# Altium schematic sheet BMSSlaveSchematic.SchDoc
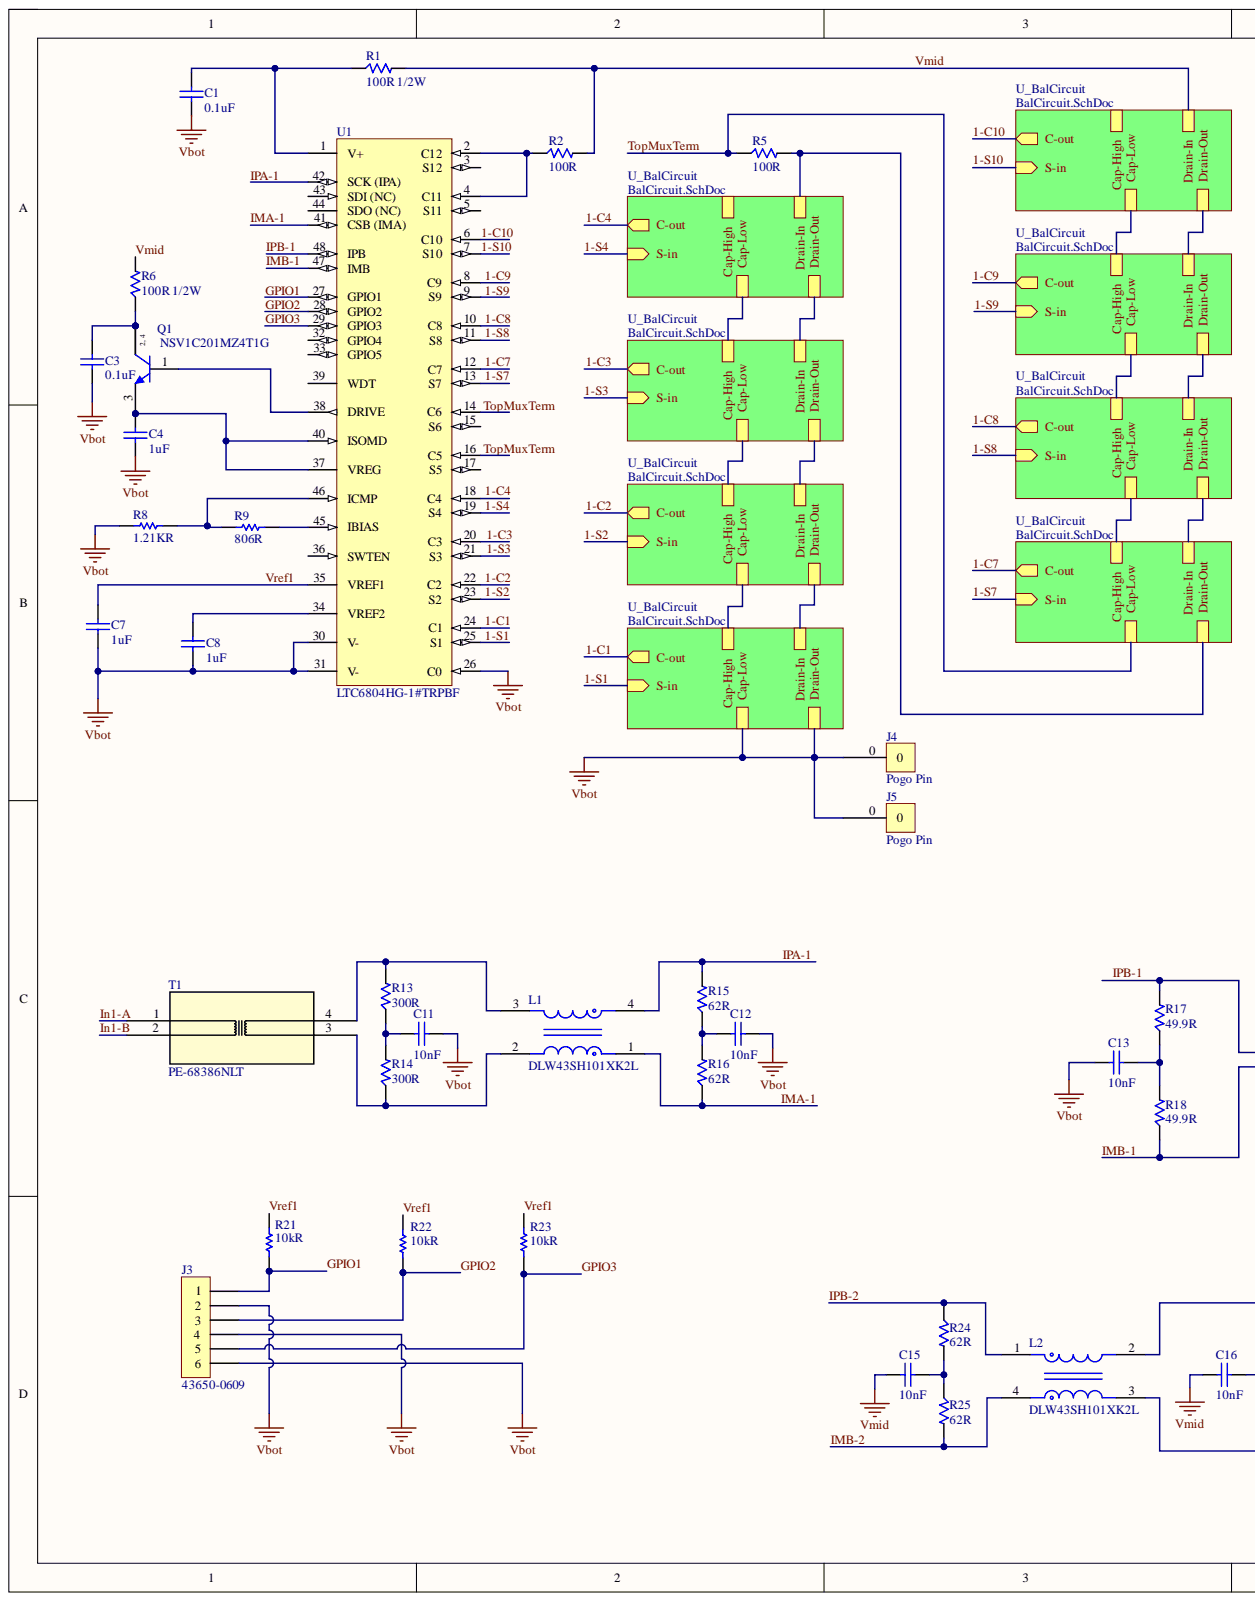
[diagram p0: BMSSlaveSchematic.SchDoc, left]
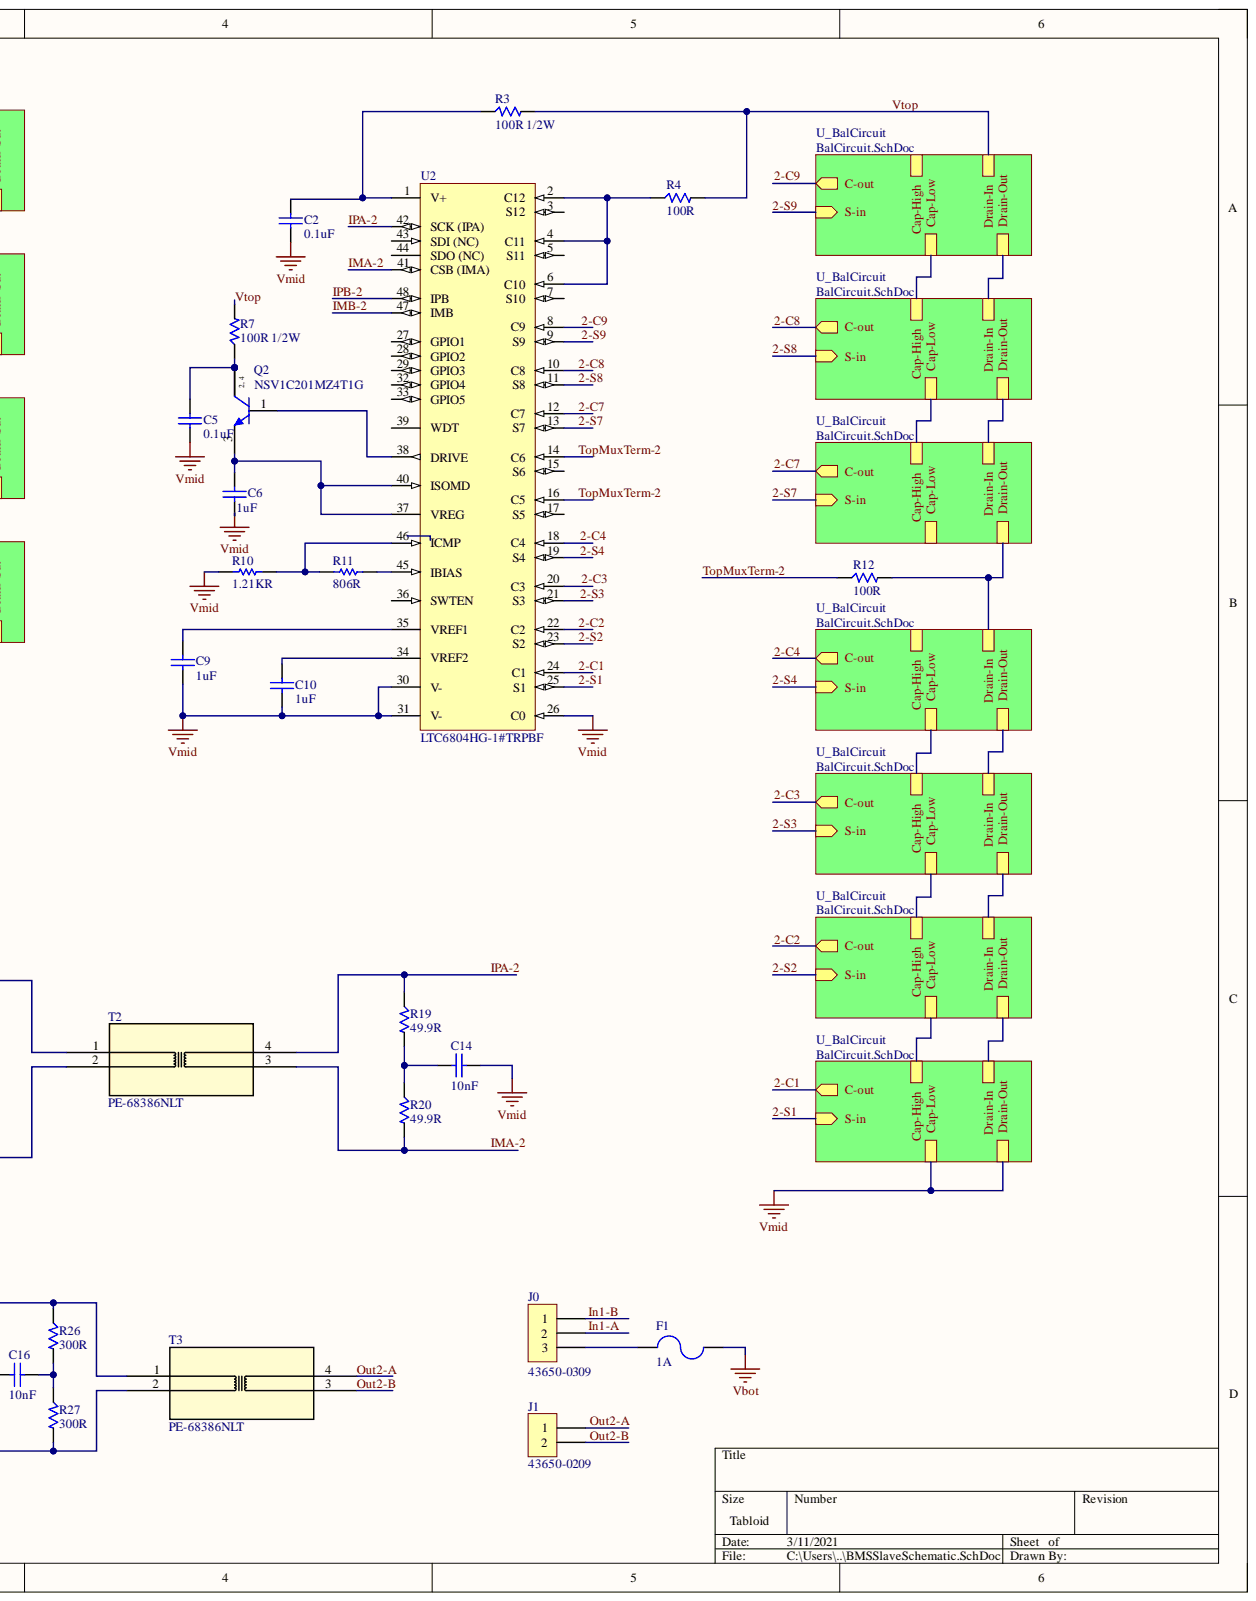
[diagram p1: BMSSlaveSchematic.SchDoc, right]

## Parts
C1  0.1uF  [CAPC2013X145X48NL20T23]
C2  0.1uF  [CAPC2013X145X48NL20T23]
C3  0.1uF  [CAPC2013X145X48NL20T23]
C4  1uF  [CAPC2013X140X50NL20T25]
C5  0.1uF  [CAPC2013X145X48NL20T23]
C6  1uF  [CAPC2013X140X50NL20T25]
C7  1uF  [CAPC2013X140X50NL20T25]
C8  1uF  [CAPC2013X140X50NL20T25]
C9  1uF  [CAPC2013X140X50NL20T25]
C10  1uF  [CAPC2013X140X50NL20T25]
C11  10nF  [Cap, 5mm TH, Ceramic]
C12  10nF  [Cap, 5mm TH, Ceramic]
C13  10nF  [Cap, 5mm TH, Ceramic]
C14  10nF  [Cap, 5mm TH, Ceramic]
C15  10nF  [Cap, 5mm TH, Ceramic]
C16  10nF  [Cap, 5mm TH, Ceramic]
F1  1A  [OMT125]
J0  43650-0309  [43650-0309]
J1  43650-0209  [43650-0209]
J3  43650-0609  [43650-0609]
J4  Pogo Pin  [0929-7]
J5  Pogo Pin  [0929-7]
L1  DLW43SH101XK2L  [FP-DLW43-0_2-t2_6-e0_6-MFG]
L2  DLW43SH101XK2L  [FP-DLW43-0_2-t2_6-e0_6-MFG]
Q1  NSV1C201MZ4T1G  [ONSC-SOT-223-4-318E-04_V]
Q2  NSV1C201MZ4T1G  [ONSC-SOT-223-4-318E-04_V]
R1  100R 1/2W  Resistance: 100R  [Th100R-12W]
R2  100R  [Q Watt]
R3  100R 1/2W  Resistance: 100R  [Th100R-12W]
R4  100R  [Q Watt]
R5  100R  [Q Watt]
R6  100R 1/2W  Resistance: 100R  [Th100R-12W]
R7  100R 1/2W  Resistance: 100R  [Th100R-12W]
R8  1.21KR  [RESC3216X70X50NL05T20]
R9  806R  [RESC3216X70X50NL05T20]
R10  1.21KR  [RESC3216X70X50NL05T20]
R11  806R  [RESC3216X70X50NL05T20]
R12  100R  [Q Watt]
R13  300R  [Q Watt]
R14  300R  [Q Watt]
R15  62R  [Q Watt]
R16  62R  [Q Watt]
R17  49.9R  [Q Watt]
R18  49.9R  [Q Watt]
R19  49.9R  [Q Watt]
R20  49.9R  [Q Watt]
R21  10kR  [RESC3216X70X50NL05T20]
R22  10kR  [RESC3216X70X50NL05T20]
R23  10kR  [RESC3216X70X50NL05T20]
R24  62R  [Q Watt]
R25  62R  [Q Watt]
R26  300R  [Q Watt]
R27  300R  [Q Watt]
T1  PE-68386NLT  [PE-68386NL_PUL]
T2  PE-68386NLT  [PE-68386NL_PUL]
T3  PE-68386NLT  [PE-68386NL_PUL]
U1  LTC6804HG-1#TRPBF  [LT-G-48_M]
U2  LTC6804HG-1#TRPBF  [LT-G-48_M]

## Nets
Vbot: C1.1 C3.2 C4.1 C7.1 C8.1 C11.1 C12.1 C13.2 F1.2 J3.2 J3.4 J3.6 J4.0 J5.0 R8.1 U1.26(C0) U1.30(V-) U1.31(V-)
1-C1: U1.24(C1)
1-C2: U1.22(C2)
1-C3: U1.20(C3)
1-C4: U1.18(C4)
1-C7: U1.12(C7)
1-C8: U1.10(C8)
1-C9: U1.8(C9)
1-C10: U1.6(C10)
1-S1: U1.25(S1)
1-S2: U1.23(S2)
1-S3: U1.21(S3)
1-S4: U1.19(S4)
1-S7: U1.13(S7)
1-S8: U1.11(S8)
1-S9: U1.9(S9)
1-S10: U1.7(S10)
2-C1: U2.24(C1)
2-C2: U2.22(C2)
2-C3: U2.20(C3)
2-C4: U2.18(C4)
2-C7: U2.12(C7)
2-C8: U2.10(C8)
2-C9: U2.8(C9)
2-S1: U2.25(S1)
2-S2: U2.23(S2)
2-S3: U2.21(S3)
2-S4: U2.19(S4)
2-S7: U2.13(S7)
2-S8: U2.11(S8)
2-S9: U2.9(S9)
GPIO1: J3.1 R21.1 U1.27(GPIO1)
GPIO2: J3.3 R22.1 U1.28(GPIO2)
GPIO3: J3.5 R23.1 U1.29(GPIO3)
IMA-1: L1.1 R16.1 U1.41(CSB_(IMA))
IMA-2: R20.2 T2.3 U2.41(CSB_(IMA))
IMB-1: R18.1 T2.2 U1.47(IMB)
IMB-2: L2.4 R25.2 U2.47(IMB)
In1-A: J0.2 T1.1
In1-B: J0.1 T1.2
IPA-1: L1.4 R15.2 U1.42(SCK_(IPA))
IPA-2: R19.1 T2.4 U2.42(SCK_(IPA))
IPB-1: R17.2 T2.1 U1.48(IPB)
IPB-2: L2.1 R24.1 U2.48(IPB)
Out2-A: J1.1 T3.4
Out2-B: J1.2 T3.3
TopMuxTerm: R5.1 U1.14(C6) U1.16(C5)
TopMuxTerm-2: R12.1 U2.14(C6) U2.16(C5)
Vmid: C2.1 C5.2 C6.1 C9.1 C10.1 C14.1 C15.1 C16.1 R1.2 R2.2 R6.2 R10.1 U2.26(C0) U2.30(V-) U2.31(V-)
Vref1: C7.2 R21.2 R22.2 R23.2 U1.35(VREF1)
Vtop: R3.2 R4.2 R7.2
NetC1_2: C1.2 R1.1 U1.1(V+)
NetC2_2: C2.2 R3.1 U2.1(V+)
NetC3_1: C3.1 Q1.2 Q1.4 R6.1
NetC4_2: C4.2 Q1.3 U1.37(VREG) U1.40(ISOMD)
NetC5_1: C5.1 Q2.2 Q2.4 R7.1
NetC6_2: C6.2 Q2.3 U2.37(VREG) U2.40(ISOMD)
NetC8_2: C8.2 U1.34(VREF2)
NetC9_2: C9.2 U2.35(VREF1)
NetC10_2: C10.2 U2.34(VREF2)
NetC11_2: C11.2 R13.1 R14.2
NetC12_2: C12.2 R15.1 R16.2
NetC13_1: C13.1 R17.1 R18.2
NetC14_2: C14.2 R19.2 R20.1
NetC15_2: C15.2 R24.2 R25.1
NetC16_2: C16.2 R26.2 R27.1
NetF1_1: F1.1 J0.3
NetL1_2: L1.2 R14.1 T1.3
NetL1_3: L1.3 R13.2 T1.4
NetL2_2: L2.2 R26.1 T3.1
NetL2_3: L2.3 R27.2 T3.2
NetQ1_1: Q1.1 U1.38(DRIVE)
NetQ2_1: Q2.1 U2.38(DRIVE)
NetR2_1: R2.1 U1.2(C12) U1.4(C11)
NetR4_1: R4.1 U2.2(C12) U2.4(C11) U2.6(C10)
NetR5_2: R5.2
NetR8_2: R8.2 R9.1 U1.46(ICMP)
NetR9_2: R9.2 U1.45(IBIAS)
NetR10_2: R10.2 R11.1 U2.46(ICMP)
NetR11_2: R11.2 U2.45(IBIAS)
NetR12_2: R12.2
NetU1_3: U1.3(S12)
NetU1_5: U1.5(S11)
NetU1_15: U1.15(S6)
NetU1_17: U1.17(S5)
NetU1_32: U1.32(GPIO4)
NetU1_33: U1.33(GPIO5)
NetU1_36: U1.36(SWTEN)
NetU1_39: U1.39(WDT)
NetU1_43: U1.43(SDI_(NC))
NetU1_44: U1.44(SDO_(NC))
NetU2_3: U2.3(S12)
NetU2_5: U2.5(S11)
NetU2_7: U2.7(S10)
NetU2_15: U2.15(S6)
NetU2_17: U2.17(S5)
NetU2_27: U2.27(GPIO1)
NetU2_28: U2.28(GPIO2)
NetU2_29: U2.29(GPIO3)
NetU2_32: U2.32(GPIO4)
NetU2_33: U2.33(GPIO5)
NetU2_36: U2.36(SWTEN)
NetU2_39: U2.39(WDT)
NetU2_43: U2.43(SDI_(NC))
NetU2_44: U2.44(SDO_(NC))
Cap-High:
Cap-Low:
Drain-In:

## Library data of the parts
C1-C3 C5 = CMP-2007-01705-1: Manufacturer: Vitramon; Part Number: VJ0805Y104KXAMR; Component Kind: Standard; Library Name: Altium Content Vault
C4 C6-C10 = CMP-1036-04765-2: Manufacturer: AVX Interconnect / Elco; Part Number: 08055G105ZAT2A; Component Kind: Standard; Library Name: Altium Content Vault
C11-C16 = Cap, 5MM TH, Ceramic: Generic Cap, 5MM TH, Ceramic; TargetLibrary: RITRacing-Passives; Value: Value?; Component Kind: Standard; Library Name: Passives.intlib; Published: 22Oct2016; Status: Active; Publisher: T.Tallo
F1 = OMT125: SCHURTER   3404.0114.11   FUSE, 1A, 125VDC, SMT; Approvals: cURus,cULus,UL; Blow Characteristic: TIME DELAY; Body Material: Thermoplastic; Fuse Size: 7.4 X 3.1mm; Fuse Type: Board Mount (Cartridge Style Excluded); Max Voltage Rating (AC): 125V; Power Dissipation: 88mW; Case/Package: SMD/SMT; Category: Fuses; Component Kind: Standard; ComponentLink1Description: https://datasheet.ciiva.com/4486/typ-omt-125-14932-4486467.pdf?src-supplier=Mouser; ComponentLink1URL: https://datasheet.ciiva.com/4486/typ-omt-125-14932-4486467.pdf?src-supplier=Mouser; ComponentLink2Description: https://datasheet.ciiva.com/26876/getdatasheetpartid-627585-26876503.pdf?src-supplier=Verical; ComponentLink2URL: https://datasheet.ciiva.com/26876/getdatasheetpartid-627585-26876503.pdf?src-supplier=Verical; ComponentLink3Description: https://datasheet.ciiva.com/17402/typ-omt-125-17402981.pdf?src-supplier=Avnet+Europe; ComponentLink3URL: https://datasheet.ciiva.com/17402/typ-omt-125-17402981.pdf?src-supplier=Avnet+Europe; ComponentLink4Description: https://datasheet.ciiva.com/1549/typ-omt-125-1549883.pdf?src-supplier=Element14; ComponentLink4URL: https://datasheet.ciiva.com/1549/typ-omt-125-1549883.pdf?src-supplier=Element14; ComponentLink5Description: https://datasheet.ciiva.com/8264/typ-omt-125-8264396.pdf?src-supplier=Avnet; ComponentLink5URL: https://datasheet.ciiva.com/8264/typ-omt-125-8264396.pdf?src-supplier=Avnet; Contact Material: Copper Alloy; Contact Plating: Tin; Current: 1A; Current Rating: 1A; Depth: 3.1mm; Flammability Rating: UL94 V-0; Fuse Current: 1A; Height: 0.102inch; Housing Material: Thermoplastic; Length: 7.4mm; Library Name: otherComponents.SchLib; Manufacturer 1: Schurter; Manufacturer Part Number 1: 3404.0114.11; Max Operating Temperature: 85°C; Max Voltage Rating (DC): 125V; Min Operating Temperature: -55°C; Mount: Surface Mount,PCB; Number of Pins: 2; Package Quantity: 100; Packaging: Bag; Pricing 1: 1=0.67000, 50=0.56400, 100=0.43100, 500=0.42300, 1000=0.38171 (USD); Radiation Hardening: No; REACH SVHC: No SVHC; RoHS: Yes; RoHS Compliant: Yes; Series: OMT 125; Stock 1: 1439; Supplier 1: Digi-Key; Terminal Material: Tin; Termination: SMD/SMT; Voltage Rating: 125V; Voltage Rating (AC): 125V; Voltage Rating (DC): 125V; Width: 0.122inch
J0 = 43650-0309: Molex 3 Position Board Header, RIght Angle; TargetLibrary: RITRacing-Connectors; Voltage: 600V; Component Kind: Standard; ComponentLink1Description: Datasheet; ComponentLink1URL: http://www.mouser.com/ds/2/418/NG_CD_1445088_A1-614413.pdf; Library Name: Connectors.IntLib; Manufacturer: Molex; PartNumber: 43650-0309; Published: 13Aug2018; Status: Active; Publisher: T.Tallo
J1 = 43650-0209: Molex 2 Position Board Header, RIght Angle; TargetLibrary: RITRacing-Connectors; Voltage: 600V; Component Kind: Standard; ComponentLink1Description: Datasheet; ComponentLink1URL: http://www.mouser.com/ds/2/418/NG_CD_1445088_A1-614413.pdf; Library Name: Connectors.IntLib; Manufacturer: Molex; PartNumber: 43650-0209; Published: 13Aug2018; Status: Active; Publisher: T.Tallo
J3 = 43650-0609: Molex 6 Position Board Header, RIght Angle; TargetLibrary: RITRacing-Connectors; Voltage: 600V; Component Kind: Standard; ComponentLink1Description: Datasheet; ComponentLink1URL: http://www.mouser.com/ds/2/418/NG_CD_1445088_A1-614413.pdf; Library Name: Connectors.IntLib; Manufacturer: Molex; PartNumber: 43650-0609; Published: 13Aug2018; Status: Active; Publisher: T.Tallo
J4 J5 = 0929-0-15-20-75-14-11-0: Component Kind: Standard; Library Name: SinglePad.SchLib
L1 L2 = CMP-06045-000059-1: CMC 100UH 200MA 2LN SMD AEC-Q200; DC Resistance (DCR): 2R; Number of Lines: 2; Shielding: Unshielded; Test Frequency: 1MHz; Tolerance: 50%; Voltage Rating: 50V; Case Code (Imperial): 1812; Case Code (Metric): 4532; Case/Package: 1812; Component Kind: Standard; Current: 200mA; Current Rating: 200mA; DC Current: 200mA; Depth: 3.4mm; Height: 2.6mm; Inductance: 100uH; Lead Free: Lead Free; Length: 4.5mm; Library Name: Altium Content Vault; Max DC Current: 200mA; Max Operating Temperature: 125°C; Military Standard: Not; Min Operating Temperature: -40°C; Mount: Surface Mount; Package Quantity: 1; Packaging: Tape and Reel; Radiation Hardening: No; REACH SVHC: No SVHC; Termination: SMD/SMT; Weight: 0.005997oz; Width: 0.126inch
Q1 Q2 = CMP-1048-00450-1: 100 V, 2 A, Low VCE(sat), NPN Transistor, 4-Pin SOT-223, Pb-Free, Tape and Reel; Manufacturer: On Semiconductor; IC Continuous (A): 2; Note1: 0; Component Kind: Standard; ComponentLink1Description: Manufacturer URL; ComponentLink1URL: http://www.onsemi.com/; ComponentLink2Description: Datasheet; ComponentLink2URL: http://www.onsemi.cn/pub_link/Collateral/NSS1C201MZ4-D.PDF; ComponentLink3Description: Package Specification; ComponentLink3URL: http://www.onsemi.com/pub_link/Collateral/318E-04.PDF; DatasheetVersion: Rev. 4; Library Name: Altium Content Vault; Mounting Technology: Surface Mount; PackageDescription: 4-Pin Small Outline Transistor, Body 6.5 x 3.5 mm, Pitch 2.3 mm; PackageReference: SOT-223-4-318E-04; PackageVersion: Rev. N, 08/2009; Packing: Tape and Reel; PartNumber: NSV1C201MZ4T1G; Polarity: NPN; RoHS: TRUE
R1 R3 R6 R7 = SFR16S0001000FR500: Res Metal Film 100 Ohm 1% 1/2W 100ppm/C Conformal AXL Thru-Hole T/R; Manufacturer 1: Vishay BCcomponents; Lead Diameter: 0.45mm; Max Power Dissipation: 500mW; Temperature Coefficient: 100ppm/°C; Voltage Rating: 200V; Case/Package: Axial; Category: Through-Hole Resistors; Component Kind: Standard; ComponentLink1Description: https://datasheet.ciiva.com/2710/sfr16s25-2710549.pdf?src-supplier=Digi-Key; ComponentLink1URL: https://datasheet.ciiva.com/2710/sfr16s25-2710549.pdf?src-supplier=Digi-Key; ComponentLink2Description: https://datasheet.ciiva.com/16427/sfr16s25-16427365.pdf?src-supplier=Future+Electronics; ComponentLink2URL: https://datasheet.ciiva.com/16427/sfr16s25-16427365.pdf?src-supplier=Future+Electronics; ComponentLink3Description: https://datasheet.ciiva.com/2710/sfr16s25-2710550.pdf?src-supplier=Digi-Key; ComponentLink3URL: https://datasheet.ciiva.com/2710/sfr16s25-2710550.pdf?src-supplier=Digi-Key; ComponentLink4Description: https://datasheet.ciiva.com/28605/1911160-28605898.pdf?src-supplier=Element14; ComponentLink4URL: https://datasheet.ciiva.com/28605/1911160-28605898.pdf?src-supplier=Element14; ComponentLink5Description: https://datasheet.ciiva.com/48523/sfr16s25-48523466.pdf?src-supplier=Avnet; ComponentLink5URL: https://datasheet.ciiva.com/48523/sfr16s25-48523466.pdf?src-supplier=Avnet; ComponentLink6Description: https://datasheet.ciiva.com/8321/sfr16s25-8321901.pdf?src-supplier=Avnet; ComponentLink6URL: https://datasheet.ciiva.com/8321/sfr16s25-8321901.pdf?src-supplier=Avnet; Contact Plating: Tin; Diameter: 1.9mm; Lead Free: Lead Free; Length: 0.138inch; Library Name: Resistors.SchLib; Manufacturer Part Number 1: SFR16S0001000FR500; Max Operating Temperature: 155°C; Min Operating Temperature: -55°C; Mount: Through Hole; Number of Terminations: 2; Package Quantity: 1; Packaging: Cut Tape; Power Rating: 500mW; Pricing 1: 1=0.19000, 10=0.15700, 25=0.11440, 50=0.08300, 100=0.06150, 250=0.04720, 500=0.03718, 1000=0.02574 (USD); Radiation Hardening: No; REACH SVHC: Unknown; Resistance Tolerance: 1%; Resistor Type: Metal Film; RoHS: Yes; RoHS Compliant: Yes; Series: SFR16S; Stock 1: 3242; Supplier 1: Digi-Key; Termination: Axial; Tolerance: 1%; Voltage Rating (DC): 200V
R2 R4 R5 R12 = RNF14FTD100R: RES 100 OHM 1/4W 1% AXIAL; Manufacturer 1: Stackpole Electronics; Max Power Dissipation: 250mW; Temperature Coefficient: 100ppm/°C; Working Voltage: 250V; Case/Package: Conformal; Category: Through-Hole Resistors; Component Kind: Standard; ComponentLink1Description: https://datasheet.ciiva.com/19454/2163891-19454777.pdf?src-supplier=Jameco; ComponentLink1URL: https://datasheet.ciiva.com/19454/2163891-19454777.pdf?src-supplier=Jameco; Construction: Cylindrical; Contact Plating: Copper,Tin; Diameter: 2.35mm; Features: Flame Retardant Coating; Lead Free: Lead Free; Length: 6.35mm; Library Name: Resistors.SchLib; Manufacturer Part Number 1: RNF14FTD100R; Max Operating Temperature: 155°C; Military Standard: Not; Min Operating Temperature: -55°C; Mount: Through Hole,Axial; Number of Terminations: 2; Package Quantity: 5000; Packaging: Tape and Reel; Power Rating: 250mW; Pricing 1: 1=0.10000, 10=0.06500, 25=0.04480, 50=0.03500, 100=0.02500, 250=0.01952, 500=0.01550, 1000=0.01100 (USD); Radiation Hardening: No; Resistance: 100R; Resistor Type: Metal Film; RoHS: Yes; RoHS Compliant: Yes; Series: RNF; Stock 1: 121720; Supplier 1: Digi-Key; Technology: METAL FILM; Termination: Axial; Tolerance: 1%
R8 R10 = ERJ-8ENF1211V: RES 1.21KR 1% 0805 1/4W; Manufacturer: Panasonic; Component Kind: Standard; Library Name: Resistors.SchLib; Part Number: ERJ-8ENF1211V
R9 R11 = ERJ-8ENF8060V: RES 806R 1% 0805 1/4W; Manufacturer: Panasonic; Component Kind: Standard; Library Name: Resistors.SchLib; Part Number: ERJ-8ENF8060V
R13 R14 R26 R27 = RNMF14FTC300R: RES 300 OHM 1/4W 1% AXIAL; Manufacturer 1: Stackpole Electronics; Max Power Dissipation: 250mW; Temperature Coefficient: 50ppm/°C; Case/Package: Axial; Category: Through-Hole Resistors; Component Kind: Standard; ComponentLink1Description: http://www.seielect.com/Catalog/SEI-RNF_RNMF.pdf?src-supplier=Avnet; ComponentLink1URL: http://www.seielect.com/Catalog/SEI-RNF_RNMF.pdf?src-supplier=Avnet; ComponentLink2Description: https://www.seielect.com/catalog/SEI-Packaging.pdf?src-supplier=Digi-Key; ComponentLink2URL: https://www.seielect.com/catalog/SEI-Packaging.pdf?src-supplier=Digi-Key; Contact Plating: Tin,Matte; Diameter: 2.35mm; Features: Flame Retardant Coating; Length: 0.13inch; Library Name: Resistors.SchLib; Manufacturer Part Number 1: RNMF14FTC300R; Max Operating Temperature: 155°C; Min Operating Temperature: -55°C; Mount: Through Hole; Number of Terminations: 2; Package Quantity: 1; Packaging: Tape and Reel; Pricing 1: 1=0.10000, 10=0.06500, 25=0.04720, 50=0.03600, 100=0.02660, 250=0.02024, 500=0.01620, 1000=0.01192 (USD); Radiation Hardening: No; Resistance: 300R; Resistor Type: Metal Film; RoHS: Yes; RoHS Compliant: Yes; Series: RNMF; Stock 1: 2958; Supplier 1: Digi-Key; Tolerance: 1%
R15 R16 R24 R25 = RNMF14FTC62R0: RES 62 OHM 1/4W 1% AXIAL; Manufacturer 1: Stackpole Electronics; Max Power Dissipation: 250mW; Temperature Coefficient: 50ppm/°C; Case/Package: Axial; Category: Through-Hole Resistors; Component Kind: Standard; ComponentLink1Description: https://www.seielect.com/catalog/SEI-Packaging.pdf?src-supplier=Digi-Key; ComponentLink1URL: https://www.seielect.com/catalog/SEI-Packaging.pdf?src-supplier=Digi-Key; Features: Flame Retardant Coating; Length: 0.13inch; Library Name: Resistors.SchLib; Manufacturer Part Number 1: RNMF14FTC62R0; Max Operating Temperature: 155°C; Min Operating Temperature: -55°C; Number of Terminations: 2; Package Quantity: 1; Packaging: Tape and Reel; Pricing 1: 1=0.10000, 10=0.06500, 25=0.04720, 50=0.03600, 100=0.02660, 250=0.02024, 500=0.01620, 1000=0.01192 (USD); Resistance: 62R; Resistor Type: Metal Film; RoHS: Yes; RoHS Compliant: Yes; Series: RNMF; Stock 1: 4795; Supplier 1: Digi-Key; Tolerance: 1%
R17-R20 = RNF14FTD49R9: RES 49.9 OHM 1/4W 1% AXIAL; Manufacturer 1: Stackpole Electronics; Max Power Dissipation: 250mW; Temperature Coefficient: 100ppm/°C; Case/Package: Axial; Category: Through-Hole Resistors; Component Kind: Standard; ComponentLink1Description: https://www.seielect.com/catalog/SEI-Packaging.pdf?src-supplier=Digi-Key; ComponentLink1URL: https://www.seielect.com/catalog/SEI-Packaging.pdf?src-supplier=Digi-Key; ComponentLink2Description: http://www.seielect.com/Catalog/SEI-RNF_RNMF.pdf?src-supplier=Digi-Key; ComponentLink2URL: http://www.seielect.com/Catalog/SEI-RNF_RNMF.pdf?src-supplier=Digi-Key; ComponentLink3Description: https://www.seielect.com/Catalog/SEI-RNF_RNMF.pdf?src-supplier=Avnet; ComponentLink3URL: https://www.seielect.com/Catalog/SEI-RNF_RNMF.pdf?src-supplier=Avnet; Contact Plating: Copper,Tin; Diameter: 2.35mm; Features: Flame Retardant Coating; Lead Free: Lead Free; Length: 0.25inch; Library Name: Resistors.SchLib; Manufacturer Part Number 1: RNF14FTD49R9; Max Operating Temperature: 155°C; Min Operating Temperature: -55°C; Mount: Through Hole,Axial; Number of Terminations: 2; Package Quantity: 5000; Packaging: Tape and Reel; Pricing 1: 1=0.10000, 10=0.06500, 25=0.04480, 50=0.03500, 100=0.02500, 250=0.01952, 500=0.01550, 1000=0.01100 (USD); Radiation Hardening: No; Resistance: 49.9R; Resistor Type: Metal Film; RoHS: Yes; RoHS Compliant: Yes; Series: RNF; Stock 1: 53600; Supplier 1: Digi-Key; Tolerance: 1%
R21-R23 = ERJ-PB6B1002V: RES SMD 10K OHM 0.1% 1/4W 0805; Manufacturer 1: Panasonic; Max Power Dissipation: 250mW; Temperature Coefficient: 50ppm/°C; Case Code (Imperial): 0805; Case Code (Metric): 2012; Case/Package: 0805; Category: Chip SMD Resistors; Component Kind: Standard; ComponentLink1Description: https://industrial.panasonic.com/sa/system/files/data/download/catalog/id_fixed-resistors_e_2.pdf?src-supplier=Digi-Key; ComponentLink1URL: https://industrial.panasonic.com/sa/system/files/data/download/catalog/id_fixed-resistors_e_2.pdf?src-supplier=Digi-Key; ComponentLink2Description: https://industrial.panasonic.com/cdbs/www-data/pdf/RDM0000/DMM0000COL5.pdf?src-supplier=Digi-Key; ComponentLink2URL: https://industrial.panasonic.com/cdbs/www-data/pdf/RDM0000/DMM0000COL5.pdf?src-supplier=Digi-Key; Features: Automotive AEC-Q200; Height - Seated (Max): 0.028inch; Length: 0.079inch; Library Name: Resistors.SchLib; Manufacturer Part Number 1: ERJ-PB6B1002V; Max Operating Temperature: 155°C; Min Operating Temperature: -55°C; Number of Terminations: 2; Packaging: Tape and Reel; Pricing 1: 1=0.33000, 10=0.27800, 25=0.20240, 50=0.14680, 100=0.10880, 250=0.08348, 500=0.06578, 1000=0.04554 (USD); Resistance: 10kR; Resistor Type: Thick Film; RoHS: Yes; Stock 1: 40490; Supplier 1: Digi-Key; Tolerance: 0.1%; Width: 0.049inch
T1-T3 = PE-68386NLT: No Description Available; Built_By: EMA_Cory; SourceLibrary: Pulse_Electronics_2019-12-18; Type: DEV; Vendor: Pulse Electronics Corporation; Component Kind: Standard; Library Name: PE68386NLT.IntLib; Manufacturer_Part_Number: PE-68386NLT; RefDes: RefDes; Copyright: Copyright (C) 2018 Accelerated Designs. All rights reserved
U1 U2 = CMP-0471-00242-1: Multicell Battery Monitor, 60 V Vin MAX, 48-pin SOP (G-48), -40 to 125 degC, Pb-Free, Tape and Reel; Manufacturer: Linear Technology; Battery Chemistry: Li-Ion, Li-Polymer, NiMH; Number of Cells: > 1000 V of stacked Li-Ion cells; Type of Charger: Battery Stack Monitor; Vin Max(V): 60; Component Kind: Standard; ComponentLink1Description: Manufacturer URL; ComponentLink1URL: http://www.linear.com/; ComponentLink2Description: Datasheet; ComponentLink2URL: http://cds.linear.com/docs/en/datasheet/680412f.pdf; ComponentLink3Description: Package Specification; ComponentLink3URL: http://cds.linear.com/docs/en/packaging/05081887_0_G48.pdf; Library Name: Altium Content Vault; Mounting Technology: Surface Mount; PackageDescription: 48-Pin Plastic SSOP, Body 12.8 x 5.3 mm, Pitch 0.5 mm; PackageReference: G-48; PackageVersion: Rev. Ã˜, 01/2011; Packing: Tape and Reel; PartNumber: LTC6804HG-1#TRPBF; RoHS: TRUE
